# Altium schematic sheet ArduinoHeaders.SchDoc
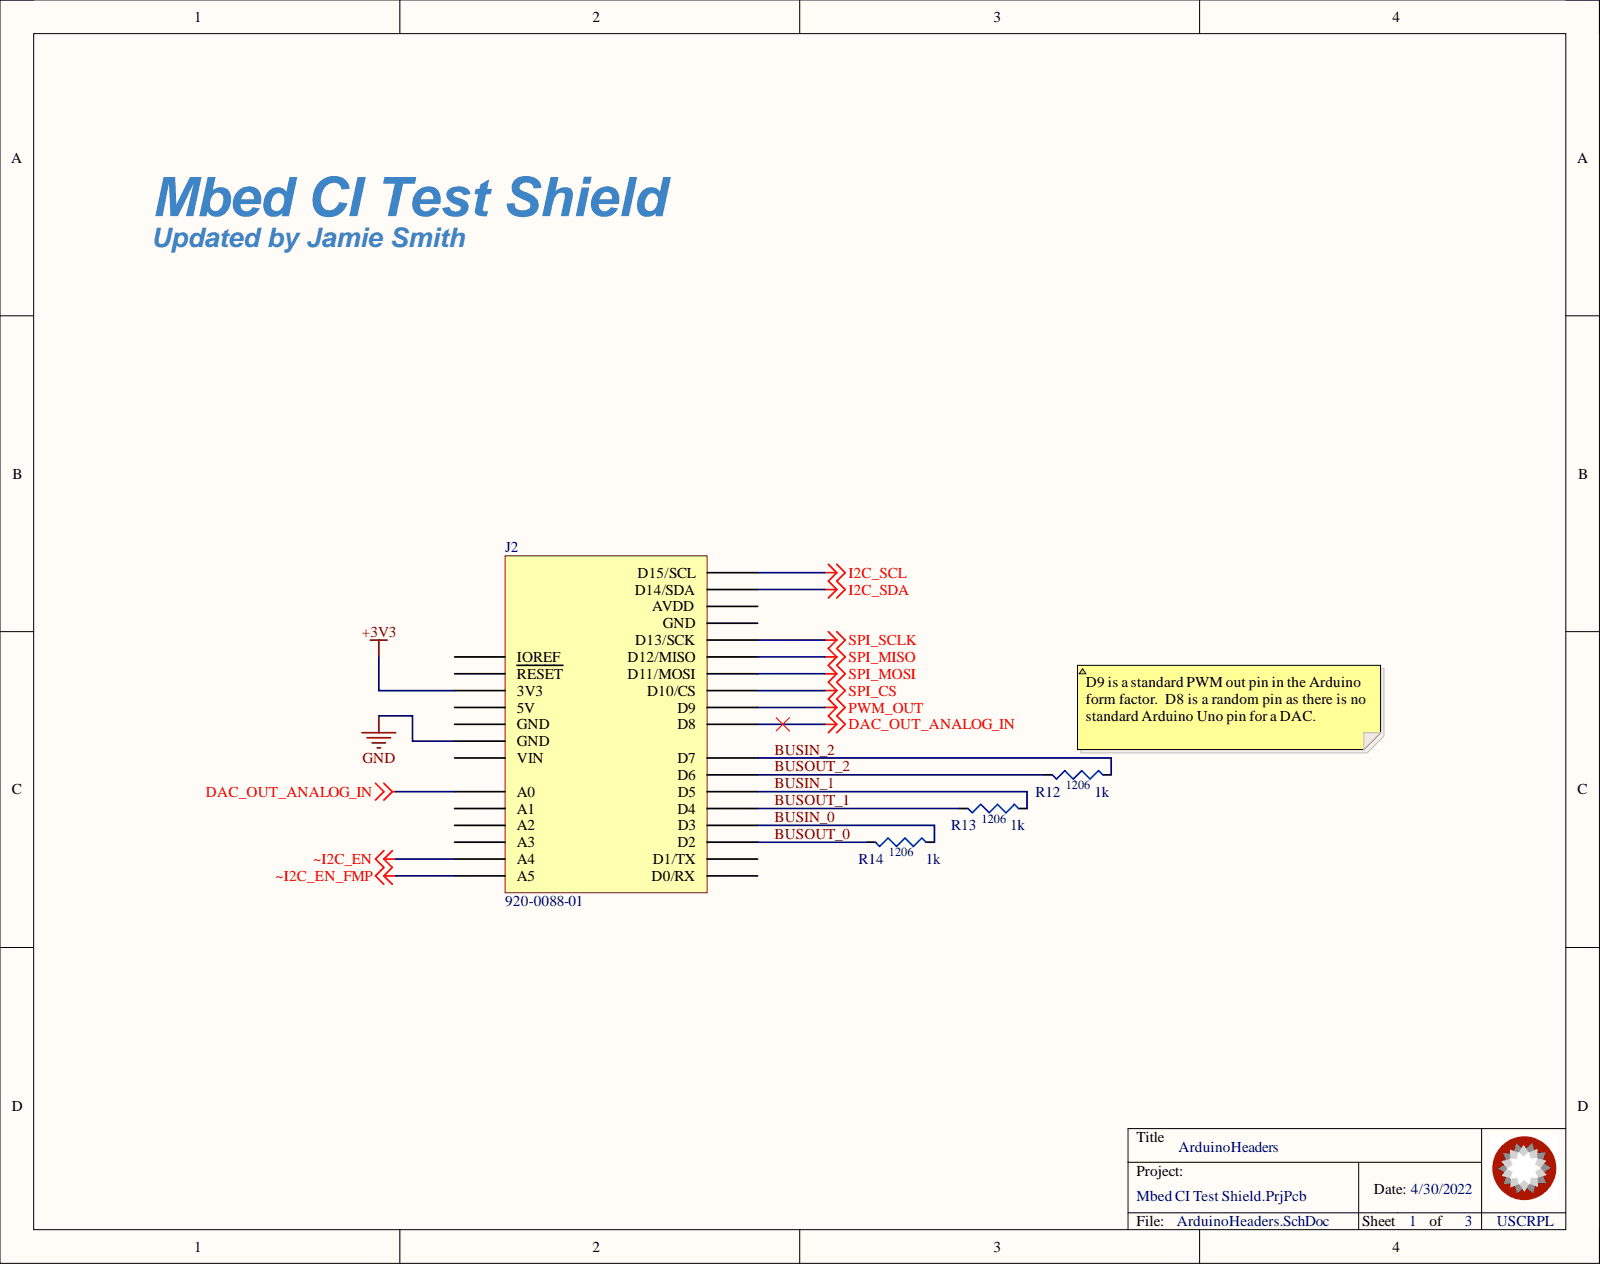
[diagram p0: ArduinoHeaders.SchDoc]

## Parts
J2  920-0088-01  [SCHMARTBOARD - 920-0088-01]
R12  1k  [R1206]
R13  1k  [R1206]
R14  1k  [R1206]

## Nets
+3V3: J2.4(3V3)
DAC_OUT_ANALOG_IN: J2.9(A0) J2.23(D8)
GND: J2.7(GND)
I2C_SCL: J2.32(D15/SCL)
I2C_SDA: J2.31(D14/SDA)
PWM_OUT: J2.24(D9)
SPI_CS: J2.25(D10/CS)
SPI_MISO: J2.27(D12/MISO)
SPI_MOSI: J2.26(D11/MOSI)
SPI_SCLK: J2.28(D13/SCK)
~I2C_EN: J2.13(A4)
~I2C_EN_FMP: J2.14(A5)
BUSIN_0: J2.18(D3) R14.2
BUSIN_1: J2.20(D5) R13.2
BUSIN_2: J2.22(D7) R12.2
BUSOUT_0: J2.17(D2) R14.1
BUSOUT_1: J2.19(D4) R13.1
BUSOUT_2: J2.21(D6) R12.1

Not connected: J2 2(IOREF) 3(RESET) 5(5V) 6(GND) 8(VIN) 10(A1) 11(A2) 12(A3) 15(D0/RX) 16(D1/TX) 29(GND) 30(AVDD)

## Library data of the parts
J2 = 920-0088-01: CONN HDR 8/10P 0.1 GOLD PCB 6PC; Manufacturer: Schmartboard, Inc.; Connector Type: Header; Contact Length - Post: 0.413" (10.50mm); Database Table Name: Connectors - Rectangular Headers Female Sockets; Number of Positions: 6, 8, 10; Number of Positions Loaded: All; Pitch - Mating: 0.100" (2.54mm); Style: Board to Board; Component Kind: Standard; ComponentLink1Description: Datasheet; ComponentLink1URL: http://schmartboard.com/content/Brochures/920-0088-01.pdf; ComponentLink2Description: DigiKey Link; ComponentLink2URL: http://digikey.com/product-detail/en/schmartboard-inc/920-0088-01/1988-1036-ND/9559319; Contact Finish - Mating: Gold; Contact Finish - Post: Gold; Contact Type: Female Socket; Fastening Type: Push-Pull; Insulation Color: Black; Insulation Height: 0.335" (8.51mm); LastUpdated: 1/31/2020 8:49:58 PM; Library Name: Celestial Altium Library - altium_library.DbLib; Lifecycle Status: Active; Minimum Order: 1; Mounting Type: Through Hole; Number of Rows: 1; Packaging: Bulk; Part Number: 920-0088-01; Part Status: Active; Price: 5.00; Series: -; Supplier 1: DigiKey; Supplier Part Number 1: 1988-1036-ND; Termination: Solder
R12-R14 = CMP-024-000033-4: 1K OHM 1% 1/4W 1206; Made By: Brennan Swanton; Reviewed By: Carter Allen; Component Kind: Standard; Design Item ID: ERJ-8ENF1001V; Source: Resistors.SchLib; Supplier 1: Digi-Key; Supplier Part Number 1: P1.00KFCT-ND; Library Name: USCRPL
